# Altium schematic sheet IMX6ULL_MINI_DEVICE.SchDoc(IMX6ULL_MINI_DEVICE)
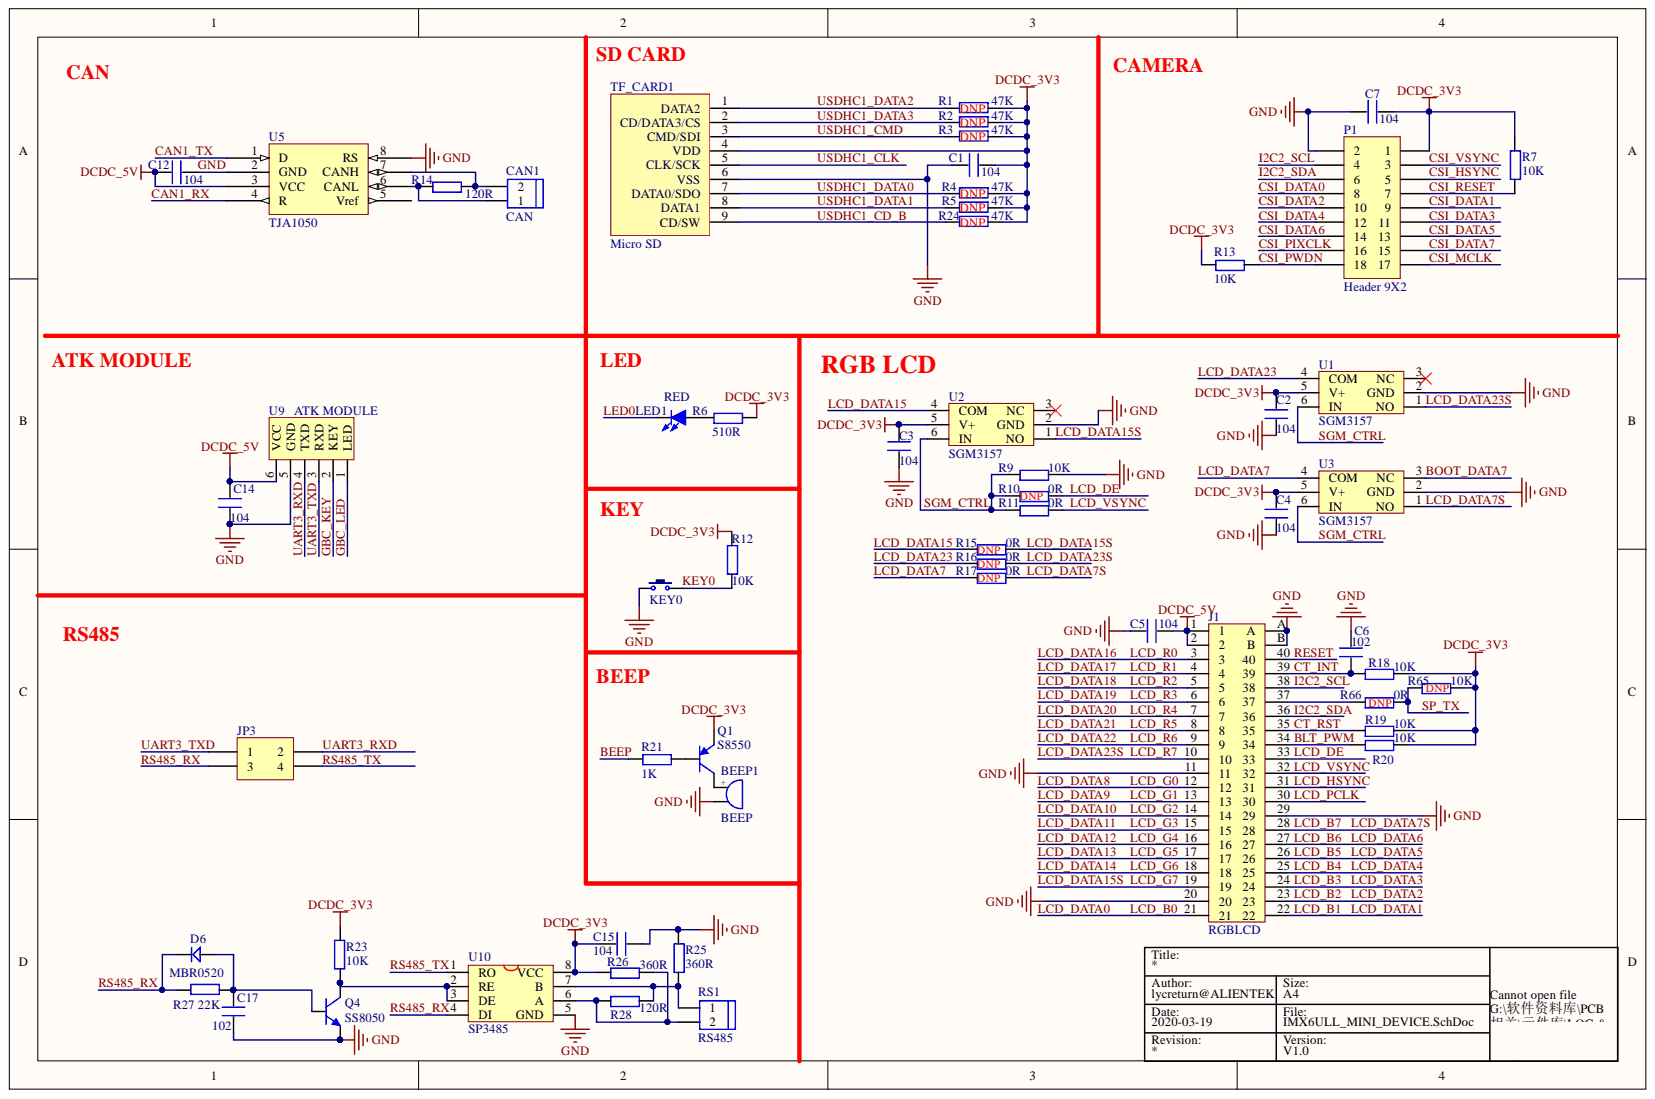
[diagram p0: IMX6ULL_MINI_DEVICE.SchDoc]

## Parts
BEEP1  BEEP  [BEEP 5x9x5.5]
C1  104  [C 0603]
C2  104  [C 0603]
C3  104  [C 0603]
C4  104  [C 0603]
C5  104  [C 0603]
C6  102  [C 0603]
C7  104  [C 0603]
C12  104  [C 0603]
C14  104  [C 0603]
C15  104  [C 0603]
C17  102  [C 0603]
CAN1  CAN  [KF301-2P]
D6  MBR0520  [SOD-123F]
J1  RGBLCD  [FPC0.5-TOP40P]
JP3  (no value)  [HDR2X2]
KEY0  KEY  [TSW DIP-6*6*5 - 2]
LED1  RED  [LED 0805R]
P1  Header 9X2  [HDR2X9]
Q1  S8550  [SOT23-3L]
Q4  SS8050  [SOT23-3N]
R1  47K  [R 0603]
R2  47K  [R 0603]
R3  47K  [R 0603]
R4  47K  [R 0603]
R5  47K  [R 0603]
R6  510R  [R 0603]
R7  10K  [R 0603]
R9  10K  [R 0603]
R10  0R  [R 0603]
R11  0R  [R 0603]
R12  10K  [R 0603]
R13  10K  [R 0603]
R14  120R  [R 0603]
R15  0R  [R 0603]
R16  0R  [R 0603]
R17  0R  [R 0603]
R18  10K  [R 0603]
R19  10K  [R 0603]
R20  10K  [R 0603]
R21  1K  [R 0603]
R23  10K  [R 0603]
R24  47K  [R 0603]
R25  360R  [R 0603]
R26  360R  [R 0603]
R27  22K  [R 0603]
R28  120R  [R 0603]
R65  10K  [R 0603]
R66  0R  [R 0603]
RS1  RS485  [KF301-2P]
TF_CARD1  Micro SD  [Micro SD]
U1  SGM3157  [SOT363-6L]
U2  SGM3157  [SOT363-6L]
U3  SGM3157  [SOT363-6L]
U5  TJA1050  [SOP8_L]
U9  ATK MODULE  [HEAD6]
U10  SP3485  [SOP8_L]

## Nets
DCDC_3V3: C1.2 C2.2 C3.2 C4.2 C7.1 C15.1 P1.1 Q1.2 R1.1 R2.1 R3.1 R4.1 R5.1 R6.2 R7.2 R12.2 R13.1 R18.2 R19.2 R20.2 R23.1 R24.1 R26.1 R65.2 TF_CARD1.4(VDD) U1.5(V+) U2.5(V+) U3.5(V+) U10.8(VCC)
DCDC_5V: C5.2 C12.2 C14.1 J1.1 J1.2 U5.3(VCC) U9.6(VCC)
BEEP: R21.1
BLT_PWM: J1.34 R20.1
BOOT_DATA7: U3.3(NC)
CAN1_RX: U5.4(R)
CAN1_TX: U5.1(D)
CSI_DATA0: P1.8
CSI_DATA1: P1.9
CSI_DATA2: P1.10
CSI_DATA3: P1.11
CSI_DATA4: P1.12
CSI_DATA5: P1.13
CSI_DATA6: P1.14
CSI_DATA7: P1.15
CSI_HSYNC: P1.5
CSI_MCLK: P1.17
CSI_PIXCLK: P1.16
CSI_PWDN: P1.18 R13.2
CSI_RESET: P1.7 R7.1
CSI_VSYNC: P1.3
CT_INT: C6.1 J1.39 R18.1
CT_RST: J1.35 R19.1
GBC_KEY: U9.2(KEY)
GBC_LED: U9.1(LED)
GND: BEEP1.2 C1.1 C2.1 C3.1 C4.1 C5.1 C6.2 C7.2 C12.1 C14.2 C15.2 C17.1 J1.11 J1.20 J1.29 J1.A J1.B KEY0.1 P1.2 Q4.2 R9.2 R25.2 TF_CARD1.6(VSS) U1.2(GND) U2.2(GND) U3.2(GND) U5.2(GND) U5.8(RS) U9.5(GND) U10.5(GND)
I2C2_SCL: J1.38 P1.4
I2C2_SDA: J1.36 P1.6
KEY0: KEY0.2 R12.1
LCD_B0: J1.21
LCD_B1: J1.22
LCD_B2: J1.23
LCD_B3: J1.24
LCD_B4: J1.25
LCD_B5: J1.26
LCD_B6: J1.27
LCD_B7: J1.28 R17.2 U3.1(NO)
LCD_DATA7: R17.1 U3.4(COM)
LCD_DATA8: J1.12
LCD_DATA9: J1.13
LCD_DATA10: J1.14
LCD_DATA11: J1.15
LCD_DATA12: J1.16
LCD_DATA13: J1.17
LCD_DATA14: J1.18
LCD_DATA15: R15.1 U2.4(COM)
LCD_DATA15S: J1.19 R15.2 U2.1(NO)
LCD_DATA16: J1.3
LCD_DATA17: J1.4
LCD_DATA18: J1.5
LCD_DATA19: J1.6
LCD_DATA20: J1.7
LCD_DATA21: J1.8
LCD_DATA22: J1.9
LCD_DATA23: R16.1 U1.4(COM)
LCD_DATA23S: J1.10 R16.2 U1.1(NO)
LCD_DE: J1.33 R10.2
LCD_HSYNC: J1.31
LCD_PCLK: J1.30
LCD_VSYNC: J1.32 R11.2
LED0: LED1.2
RESET: J1.40
RS485_RX: D6.2 JP3.3 R27.2 U10.4(DI)
RS485_TX: JP3.4 U10.1(RO)
SGM_CTRL: R9.1 R10.1 R11.1 U1.6(IN) U2.6(IN) U3.6(IN)
SP_TX: R65.1 R66.2
UART3_RXD: JP3.2 U9.4(TXD)
UART3_TXD: JP3.1 U9.3(RXD)
USDHC1_CD_B: R24.2 TF_CARD1.9(CD/SW)
USDHC1_CLK: TF_CARD1.5(CLK/SCK)
USDHC1_CMD: R3.2 TF_CARD1.3(CMD/SDI)
USDHC1_DATA0: R4.2 TF_CARD1.7(DATA0/SDO)
USDHC1_DATA1: R5.2 TF_CARD1.8(DATA1)
USDHC1_DATA2: R1.2 TF_CARD1.1(DATA2)
USDHC1_DATA3: R2.2 TF_CARD1.2(CD/DATA3/CS)
NetBEEP1_1: BEEP1.1 Q1.3
NetC17_2: C17.2 D6.1 Q4.1 R27.1
NetCAN1_1: CAN1.1 R14.1 U5.6(CANL)
NetCAN1_2: CAN1.2 R14.2 U5.7(CANH)
NetJ1_37: J1.37 R66.1
NetLED1_1: LED1.1 R6.1
NetQ1_1: Q1.1 R21.2
NetQ4_3: Q4.3 R23.2 U10.2(RE) U10.3(DE)
NetR25_1: R25.1 R28.2 RS1.1 U10.7(B)
NetR26_2: R26.2 R28.1 RS1.2 U10.6(A)

Not connected: U1 3(NC); U2 3(NC); U5 5(Vref)

## Library data of the parts
BEEP1 = BEEP: 蜂鸣器; 版权归属: 源创客; BOM名称: 蜂鸣器; Component Kind: Standard; Library Name: 未分类器件.SchLib; 学习资源: www.ooocke.com
C1-C7 C12 C14 C15 C17 = C: Component Kind: Standard; Library Name: 电阻-电容-电感.SchLib
CAN1 RS1 = HEAD2: Component Kind: Standard; Library Name: 阿波罗STM32F4&F7底板封装库.IntLib
D6 = SS14: 肖特基二极管; 属性: 40V/1A; 版权归属: 源创客; BOM名称: 肖特基二极管; Component Kind: Standard; Library Name: IMX6UL_ALPHA_V1.0.IntLib; 学习资源: www.ooocke.com
J1 = FPC-40: FPC-40P-0.5mm; Component Kind: Standard; Library Name: STM32H743_CORE.IntLib
JP3 = Header 2X2: Header, 2-Pin, Dual row; Component Kind: Standard; LatestRevisionDate: 17-Jul-2002; LatestRevisionNote: Re-released for DXP Platform.; Library Name: Miscellaneous Connectors.IntLib; Signal Integrity: Connector; Publisher: Altium Limited
KEY0 = KEY: 按键; BOM名称: 3x6轻触开关; 版权归属: 源创客; Component Kind: Standard; Library Name: 按键-开关.SchLib; 学习资源: www.ooocke.com
LED1 = LED-SMD: 贴片LED; 版权归属: 源创客; BOM名称: 贴片LED; Component Kind: Standard; Library Name: LED.SchLib; 学习资源: www.ooocke.com
P1 = Header 9X2: Header, 9-Pin, Dual row; Component Kind: Standard; LatestRevisionDate: 17-Jul-2002; LatestRevisionNote: Re-released for DXP Platform.; Library Name: Miscellaneous Connectors.IntLib; Signal Integrity: Connector; Publisher: Altium Limited
Q1 = 8550-SMD: 高频放大-PNP型; 属性: 40V 1.5A 1W 100MHZ; 版权归属: 源创客; BOM名称: 高频放大-PNP型; Component Kind: Standard; Library Name: 三极管.SchLib; 学习资源: www.ooocke.com
Q4 = 8050-SMD: 高频放大-NPN型; 属性: 40V 1.5A 1W 100MHZ; 版权归属: 源创客; BOM名称: 高频放大-NPN型; Component Kind: Standard; 学习资源: www.ooocke.com; Library Name: Lycreturn.IntLib
R1-R7 R9-R21 R23-R28 R65 R66 = R: Component Kind: Standard; Library Name: 电阻-电容-电感.SchLib
TF_CARD1 = Micro SD: Micro SD卡; 版权归属: 源创客; BOM名称: Micro SD卡; Component Kind: Standard; Library Name: IMX6UL_ZERO_V1.3.IntLib; 学习资源: www.ooocke.com
U1-U3 = SGM3157: 单路双掷模拟开关; Component Kind: Standard; Library Name: I.MX RT转接板.IntLib
U5 = SN65HVD230D: Component Kind: Standard; Library Name: 阿波罗STM32F4&F7底板封装库.IntLib
U9 = ATK-HC05: Component Kind: Standard; Description: ATK-HC05; Library Name: 阿波罗STM32F4&F7底板封装库.IntLib
U10 = MAX3485: Component Kind: Standard; Library Name: 阿波罗STM32F4&F7底板封装库.IntLib
